# Altium schematic sheet 04-Energy-Harvester.SchDoc
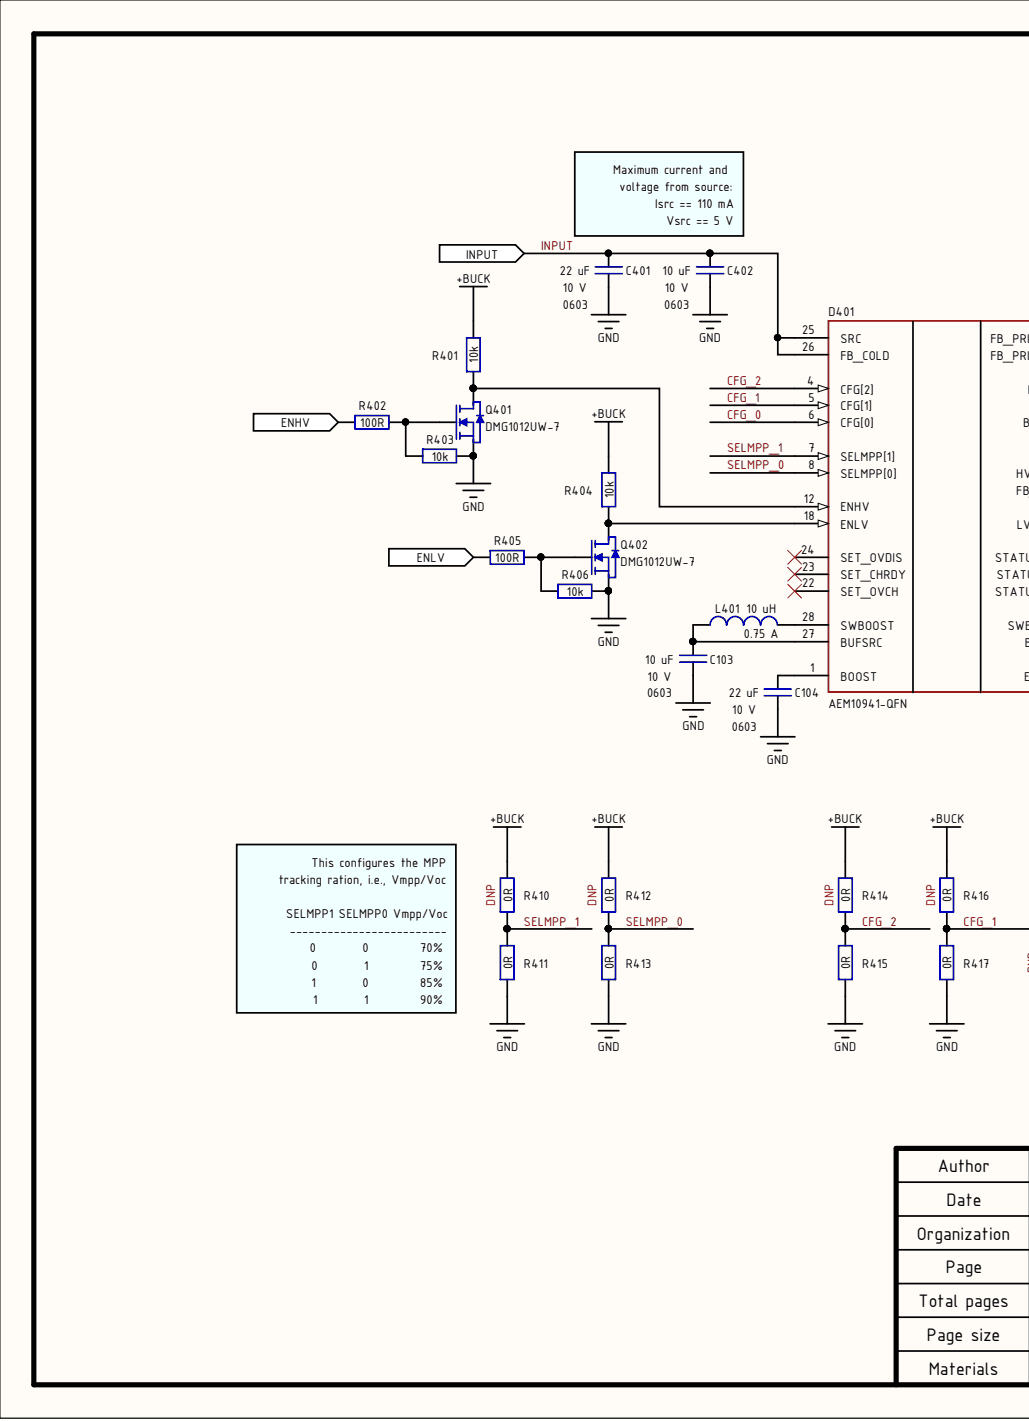
[diagram p0: 04-Energy-Harvester.SchDoc, left]
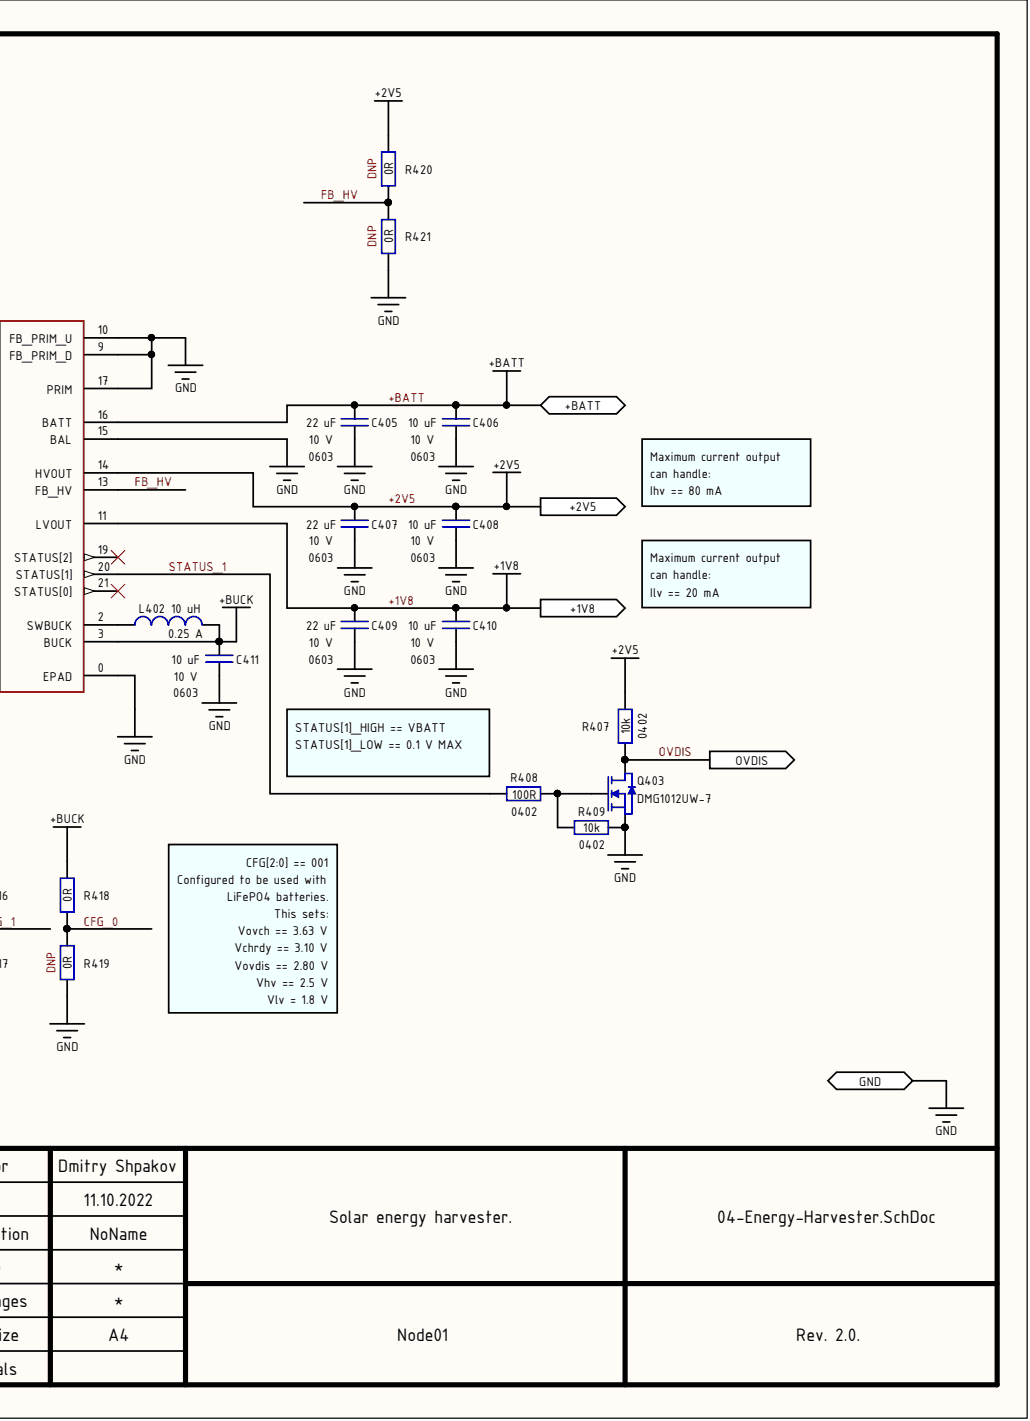
[diagram p1: 04-Energy-Harvester.SchDoc, right]

## Parts
C103  10 uF  Case: 0603  Rated Voltage: 10 V  [CAP0603HD]
C104  22 uF  Case: 0603  Rated Voltage: 10 V  [CAP0603HD]
C401  22 uF  Case: 0603  Rated Voltage: 10 V  [CAP0603HD]
C402  10 uF  Case: 0603  Rated Voltage: 10 V  [CAP0603HD]
C405  22 uF  Case: 0603  Rated Voltage: 10 V  [CAP0603HD]
C406  10 uF  Case: 0603  Rated Voltage: 10 V  [CAP0603HD]
C407  22 uF  Case: 0603  Rated Voltage: 10 V  [CAP0603HD]
C408  10 uF  Case: 0603  Rated Voltage: 10 V  [CAP0603HD]
C409  22 uF  Case: 0603  Rated Voltage: 10 V  [CAP0603HD]
C410  10 uF  Case: 0603  Rated Voltage: 10 V  [CAP0603HD]
C411  10 uF  Case: 0603  Rated Voltage: 10 V  [CAP0603HD]
D401  AEM10941-QFN  [AEM10941-QFN28]
L401  10 uH  Rated Current: 0.75 A  [LPS4012-103MRC]
L402  10 uH  Rated Current: 0.25 A  [MLZ1608M100WTD25]
Q401  DMG1012UW-7  [DMG1012UW-7]
Q402  DMG1012UW-7  [DMG1012UW-7]
Q403  DMG1012UW-7  [DMG1012UW-7]
R401  10k  [0402]
R402  100R  [0402]
R403  10k  [0402]
R404  10k  [0402]
R405  100R  [0402]
R406  10k  [0402]
R407  10k  [0402]
R408  100R  [0402]
R409  10k  [0402]
R410  0R  Assembly: DNP  [0402]
R411  0R  [0402]
R412  0R  Assembly: DNP  [0402]
R413  0R  [0402]
R414  0R  Assembly: DNP  [0402]
R415  0R  [0402]
R416  0R  Assembly: DNP  [0402]
R417  0R  [0402]
R418  0R  [0402]
R419  0R  Assembly: DNP  [0402]
R420  0R  Assembly: DNP  [0402]
R421  0R  Assembly: DNP  [0402]

## Ports
+1V8, +2V5, +BATT, ENHV, ENLV, GND, INPUT, OVDIS

## Nets
+BUCK: C411.2 D401.3(BUCK) L402.2 R401.2 R404.2 R410.2 R412.2 R414.2 R416.2 R418.2
+1V8: C409.2 C410.2 D401.11(LVOUT) ; port +1V8
+2V5: C407.2 C408.2 D401.14(HVOUT) R407.2 R420.2 ; port +2V5
+BATT: C405.2 C406.2 D401.16(BATT) ; port +BATT
CFG_0: D401.6(CFG[0]) R418.1 R419.2
CFG_1: D401.5(CFG[1]) R416.1 R417.2
CFG_2: D401.4(CFG[2]) R414.1 R415.2
ENHV: R402.1 ; port ENHV
ENLV: R405.1 ; port ENLV
FB_HV: D401.13(FB_HV) R420.1 R421.2
GND: C103.1 C104.1 C401.1 C402.1 C405.1 C406.1 C407.1 C408.1 C409.1 C410.1 C411.1 D401.0(EPAD) D401.9(FB_PRIM_D) D401.10(FB_PRIM_U) D401.15(BAL) D401.17(PRIM) Q401.S Q402.S Q403.S R403.2 R406.2 R409.2 R411.1 R413.1 R415.1 R417.1 R419.1 R421.1 ; port GND
INPUT: C401.2 C402.2 D401.25(SRC) D401.26(FB_COLD) ; port INPUT
OVDIS: Q403.D R407.1 ; port OVDIS
SELMPP_0: D401.8(SELMPP[0]) R412.1 R413.2
SELMPP_1: D401.7(SELMPP[1]) R410.1 R411.2
STATUS_1: D401.20(STATUS[1]) R408.1
NetC103_2: C103.2 D401.27(BUFSRC) L401.1
NetC104_2: C104.2 D401.1(BOOST)
NetD401_2: D401.2(SWBUCK) L402.1
NetD401_12: D401.12(ENHV) Q401.D R401.1
NetD401_18: D401.18(ENLV) Q402.D R404.1
NetD401_28: D401.28(SWBOOST) L401.2
NetQ401_G: Q401.G R402.2 R403.1
NetQ402_G: Q402.G R405.2 R406.1
NetQ403_G: Q403.G R408.2 R409.1

Not connected: D401 19(STATUS[2]) 21(STATUS[0]) 22(SET_OVCH) 23(SET_CHRDY) 24(SET_OVDIS)

## Library data of the parts
C103 C402 C406 C408 C410 C411 = GRM188R61A106ME69D: CAP CER 10UF 10V X5R 0603; Manufacturer: Murata Electronics; Other: 10 µF ±20% 10V Ceramic Capacitor X5R 0603 (1608 Metric); Power Dissipation: * W; Rated Current: * A; Summary: capacitor GRM188R61A106ME69D Murata Electronics 10 uF 20 % -55 °C to +85 °C 0603 * W * A * W 10 V 10 µF ±20% 10V Ceramic Capacitor X5R 0603 (1608 Metric); Tolerance: 20 %; Type: capacitor; Component Kind: Standard; Default Comment: 10 uF; HelpURL: https://www.digikey.com/en/products/detail/murata-electronics/GRM188R61A106ME69D/5027560; Library Name: Capacitors.SchLib; Operating Temperature: -55 °C to +85 °C; Part Number: GRM188R61A106ME69D; Rated Power: * W; Value: 10 uF
C104 C401 C405 C407 C409 = GRM188R61A226ME15J: CAP CER 22UF 10V X5R 0603; Manufacturer: Murata Electronics; Other: 22 µF ±20% 10V Ceramic Capacitor X5R 0603 (1608 Metric); Power Dissipation: * W; Rated Current: * A; Summary: capacitor GRM188R61A226ME15J Murata Electronics 22 uF 20 % -55 °C to +85 °C 0603 * W * A * W 10 V 22 µF ±20% 10V Ceramic Capacitor X5R 0603 (1608 Metric); Tolerance: 20 %; Type: capacitor; Component Kind: Standard; Default Comment: 22 uF; HelpURL: https://www.digikey.com/en/products/detail/murata-electronics/GRM188R61A226ME15J/6605932; Library Name: Capacitors.SchLib; Operating Temperature: -55 °C to +85 °C; Part Number: GRM188R61A226ME15J; Rated Power: * W; Value: 22 uF
D401 = AEM10941: Power Management Specialised - PMIC AEM10941 IC - Photovoltaic Energy Harvesting Manager; Manufacturer: e-peas; Power Dissipation: * W; Rated Current: Input: 110 mA, Output: 20 mA 80 mA; Rated Voltage: 1.2 to 4.5 V; Summary: IC AEM10941-QFN e-peas * * % -40 °C to +125 °C QFN-28 * W Input: 110 mA, Output: 20 mA 80 mA * W 1.2 to 4.5 V Power Management Specialised - PMIC AEM10941 IC - Photovoltaic Energy Harvesting Manager; Tolerance: * %; Case: QFN-28; Component Kind: Standard; Default Comment: AEM10941-QFN; HelpURL: https://eu.mouser.com/ProductDetail/e-peas/AEM10941-QFN?qs=W%2FMpXkg%252BdQ7CeqB2lBPbRQ%3D%3D; Library Name: ICs.SchLib; Operating Temperature: -40 °C to +125 °C; Other: Power Management Specialised - PMIC AEM10941 IC - Photovoltaic Energy Harvesting Manager; Part Number: AEM10941-QFN; Rated Power: * W; Type: IC
L401 = LPS4012-103MRC: Fixed Inductors 10uH Shld 20% 1.1A 350 mOhms; Manufacturer: Coilcraft; Other: Fixed inductor, 10 uH, Isat=1.1A, Imax=0.75A, Rmax=0.35Ohm Fsr=40MHz,; Power Dissipation: * W; Rated Voltage: * V; Summary: inductor LPS4012-103MRC Coilcraft 10 uH 20 % -40 °C to +85 °C 1515 (4040 metric) * W 0.75 A * W * V Fixed inductor, 10 uH, Isat=1.1A, Imax=0.75A, Rmax=0.35Ohm Fsr=40MHz,; Case: 1515 (4040 metric); Component Kind: Standard; Default Comment: 10 uH; HelpURL: https://eu.mouser.com/ProductDetail/Coilcraft/LPS4012-103MRC?qs=QQJxVsr8EGanxcNaj8pdMQ%3D%3D; Library Name: Inductors.SchLib; Operating Temperature: -40 °C to +85 °C; Part Number: LPS4012-103MRC; Rated Power: * W; Tolerance: 20 %; Type: inductor; Value: 10 uH
L402 = MLZ1608M100WTD25: FIXED IND 10UH 250MA 1.05OHM SMD; Manufacturer: TDK Corporation; Other: 10 µH Shielded Multilayer Inductor 250 mA 1.05Ohm 0603 (1608 Metric); Power Dissipation: * W; Rated Voltage: * V; Summary: inductor MLZ1608M100WTD25 TDK Corporation 10 uH 20 % -55 °C to +125 °C 0603 * W 0.25 A * W * V 10 µH Shielded Multilayer Inductor 250 mA 1.05Ohm 0603 (1608 Metric); Tolerance: 20 %; Type: inductor; Case: 0603; Component Kind: Standard; Default Comment: 10 uH; HelpURL: https://www.digikey.com/en/products/detail/tdk-corporation/MLZ1608M100WTD25/4743176; Library Name: Inductors.SchLib; Operating Temperature: -55 °C to +125 °C; Part Number: MLZ1608M100WTD25; Rated Power: * W; Value: 10 uH
Q401-Q403 = DMG1012UW-7: MOSFET N-CH 20V 1A SOT323; Manufacturer: Diodes Incorporated; Other: N-Channel 20 V 1A (Ta) 290mW (Ta) Surface Mount SOT-323; Power Dissipation: 290 mW; Summary: MOSFET DMG1012UW-7 Diodes Incorporated N-channel * % -55 °C to +150 °C SOT-323 290 mW 1 A 290 mW 20 V N-Channel 20 V 1A (Ta) 290mW (Ta) Surface Mount SOT-323; Tolerance: * %; Value: N-channel; Case: SOT-323; Component Kind: Standard; Default Comment: DMG1012UW-7; HelpURL: https://www.digikey.com/en/products/detail/diodes-incorporated/DMG1012UW-7/2183239?s=N4IgTCBcDaICIFkDiBGADCsBVA6gWgHYQBdAXyA; Library Name: Transistors.SchLib; Operating Temperature: -55 °C to +150 °C; Part Number: DMG1012UW-7; Rated Current: 1 A; Rated Power: 290 mW; Rated Voltage: 20 V; Type: MOSFET
R401 R403 R404 R406 R407 R409 = RC0402JR-0710KL: RES 10K OHM 5% 1/16W 0402; Manufacturer: YAGEO; Other: 10 kOhms ±5% 0.063W, 1/16W Chip Resistor 0402 (1005 Metric) Moisture Resistant Thick Film; Rated Current: * A; Rated Voltage: * V; Summary: resistor RC0402JR-0710KL YAGEO 10k 5 % -55 °C to +155 °C 0402 1/16 W * A 1/16 W * V 10 kOhms ±5% 0.063W, 1/16W Chip Resistor 0402 (1005 Metric) Moisture Resistant Thick Film; Case: 0402; Component Kind: Standard; Default Comment: 10k; HelpURL: https://www.digikey.com/en/products/detail/yageo/RC0402JR-0710KL/726418; Library Name: Resistors.SchLib; Operating Temperature: -55 °C to +155 °C; Part Number: RC0402JR-0710KL; Power Dissipation: 1/16 W; Rated Power: 1/16 W; Tolerance: 5 %; Type: resistor; Value: 10k
R402 R405 R408 = RC0402FR-07100RL: RES 100 OHM 1% 1/16W 0402; Manufacturer: YAGEO; Other: 100 Ohms ±1% 0.063W, 1/16W Chip Resistor 0402 (1005 Metric) Moisture Resistant Thick Film; Rated Current: * A; Rated Voltage: * V; Summary: resistor RC0402FR-07100RL YAGEO 100R 1 % -55 °C to +155 °C 0402 1/16 W * A 1/16 W * V 100 Ohms ±1% 0.063W, 1/16W Chip Resistor 0402 (1005 Metric) Moisture Resistant Thick Film; Case: 0402; Component Kind: Standard; Default Comment: 100R; HelpURL: https://www.digikey.com/en/products/detail/yageo/RC0402FR-07100RL/726527; Library Name: Resistors.SchLib; Operating Temperature: -55 °C to +155 °C; Part Number: RC0402FR-07100RL; Power Dissipation: 1/16 W; Rated Power: 1/16 W; Tolerance: 1 %; Type: resistor; Value: 100R
R410-R421 = RC0402FR-070RL: RES 0 OHM JUMPER 1/16W 0402; Manufacturer: YAGEO; Other: 0 Ohms Jumper 0.063W, 1/16W Chip Resistor 0402 (1005 Metric) Moisture Resistant Thick Film; Rated Current: * A; Rated Voltage: * V; Summary: resistor RC0402FR-070RL YAGEO 0R jumper -55 °C to +155 °C 0402 1/16 W * A 1/16 W * V 0 Ohms Jumper 0.063W, 1/16W Chip Resistor 0402 (1005 Metric) Moisture Resistant Thick Film; Case: 0402; Component Kind: Standard; Default Comment: 0R; HelpURL: https://www.digikey.com/en/products/detail/yageo/RC0402FR-070RL/2827570; Library Name: Resistors.SchLib; Operating Temperature: -55 °C to +155 °C; Part Number: RC0402FR-070RL; Power Dissipation: 1/16 W; Rated Power: 1/16 W; Tolerance: jumper; Type: resistor; Value: 0R
